# Altium schematic sheet power_supply.SchDoc
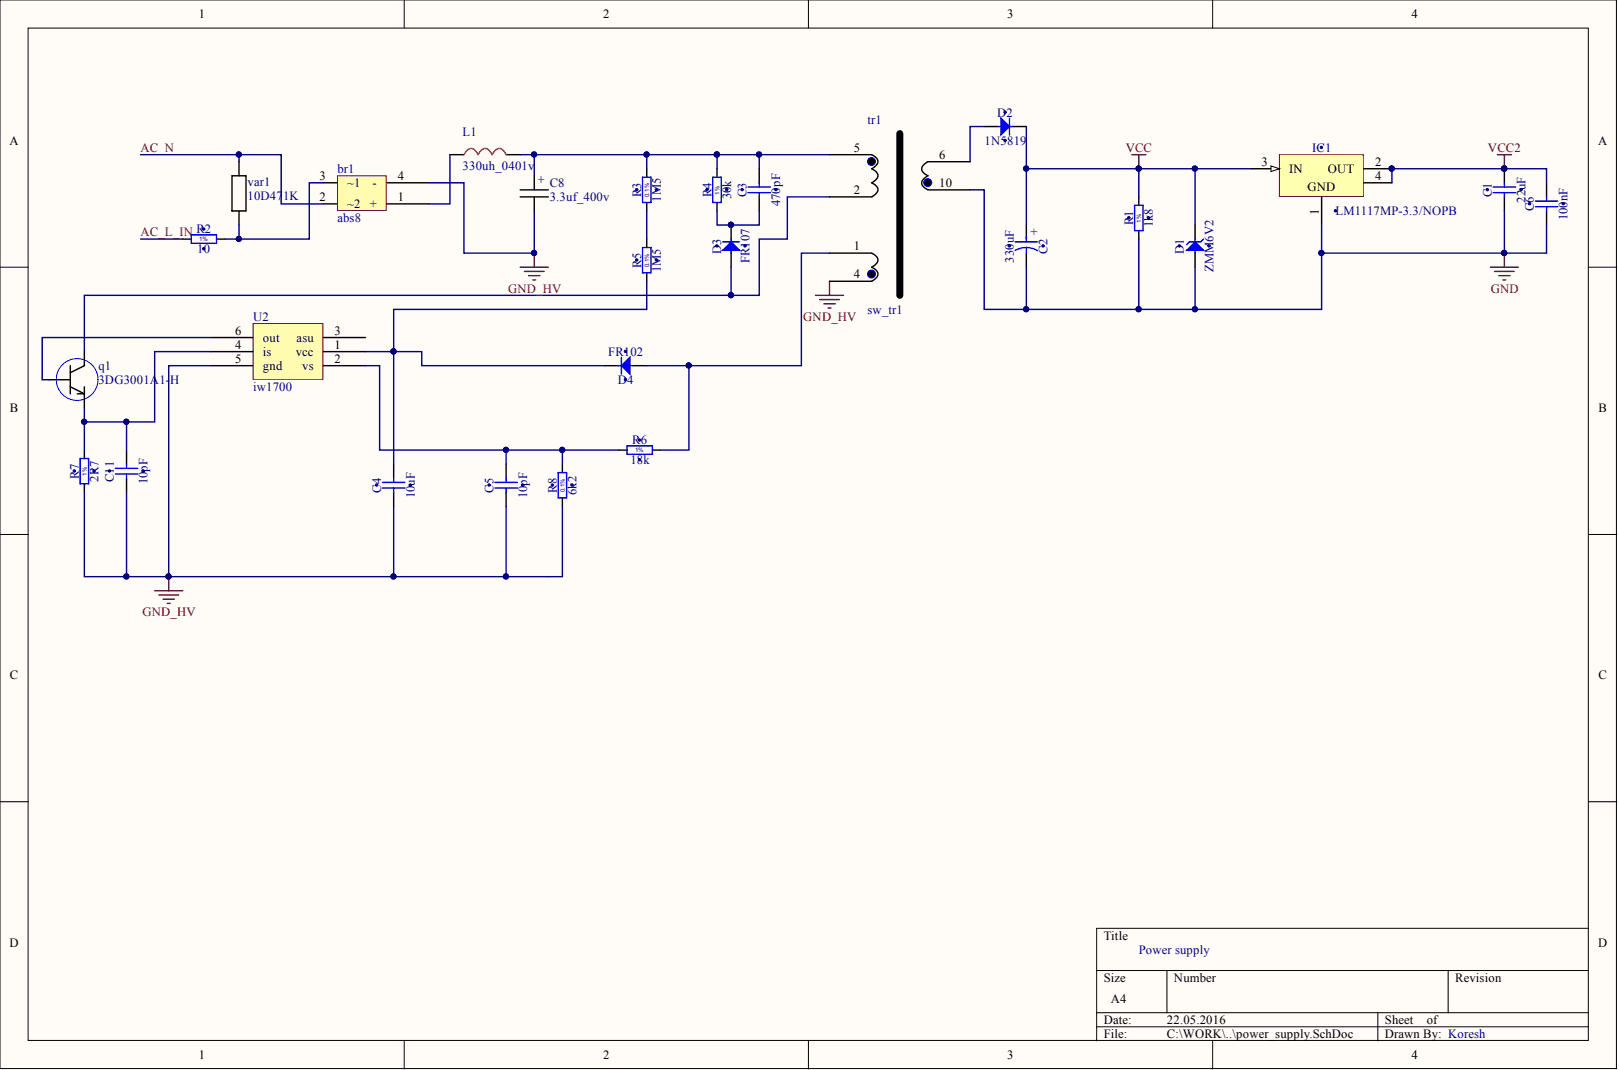
[diagram p0: power_supply.SchDoc]

## Parts
br1  abs8  [abs8]
C1  22uF  [CAPC3216X180N]
C2  330uF  [CAPMP7343X310N]
C3  470pF  [CAPC1608X87N]
C4  10uF  [CAPC2012X135N]
C5  10pF  [CAPC1608X87N]
C6  100nF  [CAPC1608X87N]
C8  3.3uf_400v  [3.3uf_400v]
C11  10pF  [CAPC1005X55N]
D1  ZMM6V2  [DIOMELF3516N]
D2  1N5819  [DIOM5227X270N]
D3  FR107  [DIOM5227X270N]
D4  FR102  [DIOM5227X270N]
IC1  LM1117MP-3.3/NOPB  [SOT230P700X180-4N]
L1  330uh_0401v  [330uh_0401v]
q1  3DG3001A1-H  [TO-92AA-P254]
R1  1k8  [RESC1608X55N]
R2  10  [RESC6331X70N]
R3  1M5  [RESC3216X65N]
R4  30k  [RESC1608X55N]
R5  1M5  [RESC3216X65N]
R6  18k  [RESC1608X55N]
R7  2R7  [RESC2012X60N]
R8  6k2  [RESC1608X55N]
tr1  sw_tr1  [sw_tr1]
U2  iw1700  [SOT95P280X100-6N]
var1  10D471K  [10D471K]

## Nets
GND: C1.1 C2.2 C6.1 D1.2 IC1.1 R1.1 tr1.10
GND_HV: br1.4(-) C4.1 C5.1 C8.2 C11.1 R7.1 R8.1 tr1.4 U2.5(gnd)
VCC: C2.1 D1.1 D2.1 IC1.3(IN) R1.2
VCC2: C1.2 C6.2 IC1.2(OUT) IC1.4
AC_L_IN: R2.1
AC_N: br1.2(~2) var1.2
Netbr1_1: br1.1(+) L1.1
Netbr1_3: br1.3(~1) R2.2 var1.1
NetC3_1: C3.1 D3.1 R4.1
NetC3_2: C3.2 C8.1 L1.2 R3.2 R4.2 tr1.5
NetC4_2: C4.2 D4.1 R5.1 U2.1(vcc)
NetC5_2: C5.2 R6.1 R8.2 U2.2(vs)
NetC11_2: C11.2 q1.3 R7.2 U2.4(is)
NetD2_2: D2.2 tr1.6
NetD3_2: D3.2 q1.2 tr1.2
NetD4_2: D4.2 R6.2 tr1.1
Netq1_1: q1.1 U2.6(out)
NetR3_1: R3.1 R5.2

Not connected: U2 3(asu)

## Library data of the parts
C1 = CC1206_22UF_10V_10%_X7R: Non-polarised capacitor; Manufacturer: GENERIC; Manufacturer1 Part Number: GRM31CR71A226KE15L; Part Description: SMD Multilayer Chip Ceramic Capacitor; Database Table Name: Capacitors SMD; TC: X7R; Tolerance: ±10%; Voltage: 10V; Case: 1206; Component Kind: Standard; Component Type: Standard; CreateDate: 08.06.2010; HelpURL: \\cern.ch\dfs\Applications\Altium\Datasheets\CC1206_X7R_MURATA_GRM-HI-CAP.pdf; LatestRevisionDate: 08.06.2010; Library Name: Capacitors.DbLib; Manufacturer1 ComponentHeight: 1.8mm; Manufacturer1 Example: MURATA; Manufacturer Part Number: CC1206_22UF_10V_10%_X7R; Mounted: Yes; Part Number: CC1206_22UF_10V_10%_X7R; Pin Count: 2; SMD: Yes; Socket: No; Status: Preferred; Table: Capacitors SMD; Value: 22uF; Author: CERN DEM JLC
C2 = CTE7343-31_330UF_6.3V_20%_LESR0025_KEMET_T520: Polarised capacitor; Manufacturer: KEMET; Manufacturer Part Number: T520D337M006ATE025; Part Description: Low ESR 0R025 Tantalum Chip Capacitor; Database Table Name: Capacitors SMD; Tolerance: ±20%; Voltage: 6.3V; Case: D; ComponentHeight: 3.1mm; Component Kind: Standard; Component Type: Standard; CreateDate: 20.10.2010; HelpURL: \\cern.ch\dfs\Applications\Altium\Datasheets\CTE-LESR_KEMET_T520.pdf; LatestRevisionDate: 20.10.2010; Library Name: Capacitors.DbLib; Mounted: Yes; Part Number: CTE7343-31_330UF_6.3V_20%_LESR0025_KEMET_T520; Pin Count: 2; SMD: Yes; Socket: No; Status: None; Table: Capacitors SMD; Value: 330uF; Author: CERN DEM JLC
C3 = CC0603_470PF_50V_5%_NP0: Non-polarised capacitor; Manufacturer: GENERIC; Manufacturer1 Part Number: 223886715471; Part Description: SMD Multilayer Chip Ceramic Capacitor; Database Table Name: Capacitors SMD; TC: NP0; Tolerance: ±5%; Voltage: 50V; Case: 0603; Component Kind: Standard; Component Type: Standard; CreateDate: 03.12.2007; HelpURL: \\cern.ch\dfs\Applications\Altium\Datasheets\CC0603_NP0_PHYCOMP.pdf; LatestRevisionDate: 03.12.2007; Library Name: Capacitors.DbLib; Manufacturer1 ComponentHeight: 0.87mm; Manufacturer1 Example: PHYCOMP; Manufacturer Part Number: CC0603_470PF_50V_5%_NP0; Mounted: Yes; Part Number: CC0603_470PF_50V_5%_NP0; Pin Count: 2; SMD: Yes; Socket: No; Status: Preferred; Table: Capacitors SMD; Value: 470pF; Author: CERN DEM JLC
C4 = CC0805_10UF_25V_10%_X5R: Non-polarised capacitor; Manufacturer: GENERIC; Manufacturer1 Part Number: C2012X5R1E106K125AB; Part Description: SMD Multilayer Chip Ceramic Capacitor; Database Table Name: Capacitors SMD; TC: X5R; Tolerance: ±10%; Voltage: 25V; Case: 0805; Component Kind: Standard; Component Type: Standard; CreateDate: 25.10.2012; HelpURL: \\cern.ch\dfs\Applications\Altium\Datasheets\CC0805_X5R_TDK_C.pdf; LatestRevisionDate: 25.10.2012; Library Name: Capacitors.DbLib; Manufacturer1 ComponentHeight: 1.4mm; Manufacturer1 Example: TDK; Manufacturer Part Number: CC0805_10UF_25V_10%_X5R; Mounted: Yes; Part Number: CC0805_10UF_25V_10%_X5R; Pin Count: 2; SMD: Yes; Socket: No; Status: Preferred; Table: Capacitors SMD; Value: 10uF; Author: CERN DEM JLC
C5 = CC0603_10PF_50V_5%_NP0: Non-polarised capacitor; Manufacturer: GENERIC; Manufacturer1 Part Number: 223886715109; Part Description: SMD Multilayer Chip Ceramic Capacitor; Database Table Name: Capacitors SMD; TC: NP0; Tolerance: ±5%; Voltage: 50V; Case: 0603; Component Kind: Standard; Component Type: Standard; CreateDate: 03.12.2007; HelpURL: \\cern.ch\dfs\Applications\Altium\Datasheets\CC0603_NP0_PHYCOMP.pdf; LatestRevisionDate: 03.12.2007; Library Name: Capacitors.DbLib; Manufacturer1 ComponentHeight: 0.87mm; Manufacturer1 Example: PHYCOMP; Manufacturer Part Number: CC0603_10PF_50V_5%_NP0; Mounted: Yes; Part Number: CC0603_10PF_50V_5%_NP0; Pin Count: 2; SMD: Yes; Socket: No; Status: Preferred; Table: Capacitors SMD; Value: 10pF; Author: CERN DEM JLC
C6 = CC0603_100NF_50V_10%_X7R: Non-polarised capacitor; Manufacturer: GENERIC; Manufacturer1 Part Number: 223858615649; Part Description: SMD Multilayer Chip Ceramic Capacitor; Database Table Name: Capacitors SMD; TC: X7R; Tolerance: ±10%; Voltage: 50V; Case: 0603; Component Kind: Standard; Component Type: Standard; CreateDate: 03.12.2007; HelpURL: \\cern.ch\dfs\Applications\Altium\Datasheets\CC0603_X7R_PHYCOMP.pdf; LatestRevisionDate: 03.12.2007; Library Name: Capacitors.DbLib; Manufacturer1 ComponentHeight: 0.87mm; Manufacturer1 Example: PHYCOMP; Manufacturer Part Number: CC0603_100NF_50V_10%_X7R; Mounted: Yes; Part Number: CC0603_100NF_50V_10%_X7R; Pin Count: 2; SMD: Yes; Socket: No; Status: Preferred; Table: Capacitors SMD; Value: 100nF; Author: CERN DEM JLC
C11 = CC0402_10PF_50V_5%_NP0: Non-polarised capacitor; Manufacturer: GENERIC; Manufacturer1 Part Number: 223886915109; Part Description: SMD Multilayer Chip Ceramic Capacitor; Database Table Name: Capacitors SMD; TC: NP0; Tolerance: ±5%; Voltage: 50V; Case: 0402; Component Kind: Standard; Component Type: Standard; CreateDate: 03.12.2007; HelpURL: \\cern.ch\dfs\Applications\Altium\Datasheets\CC0402_NP0_PHYCOMP.pdf; LatestRevisionDate: 03.12.2007; Library Name: Capacitors.DbLib; Manufacturer1 ComponentHeight: 0.55mm; Manufacturer1 Example: PHYCOMP; Manufacturer Part Number: CC0402_10PF_50V_5%_NP0; Mounted: Yes; Part Number: CC0402_10PF_50V_5%_NP0; Pin Count: 2; SMD: Yes; Socket: No; Status: Not Recommended; Table: Capacitors SMD; Value: 10pF; Author: CERN DEM JLC
D1 = CDLL4689E3: Zener Diode; Manufacturer: MICROSEMI; Part Description: Low Operating Current Zener Diode; Database Table Name: Diodes; Power: 500mW; Voltage: 5V1; Case: SOD-80; ComponentHeight: 1.7mm; Component Kind: Standard; Component Type: Standard; CreateDate: 11.04.2011; Device: ZMM6V2; HelpURL: \\cern.ch\dfs\Applications\Altium\Datasheets\CDLL4689E3.pdf; LatestRevisionDate: 11.04.2011; Library Name: ICs And Semiconductors.DbLib; Manufacturer Part Number: ZMM6V2; Mounted: Yes; PackageDescription: SOD80, Diode, MELF; 3.50mm L X 1.65mm Dia, IPC Medium Density; Part Number: ZMM6V2; Pin Count: 2; SMD: Yes; Socket: No; Table: Diodes; Author: CERN DEM JLC
D2 D3 = S1M: Diode; Manufacturer: FAIRCHILD SEMICONDUCTOR; Part Description: Surface Mount Glass Passivated Rectifier; Database Table Name: Diodes; Power: 1A; Voltage: 1000V; Case: DO-214AC; ComponentHeight: 2.29mm; Component Kind: Standard; Component Type: Standard; CreateDate: 03.10.2011; HelpURL: \\cern.ch\dfs\Applications\Altium\Datasheets\S1M.pdf; LatestRevisionDate: 13.06.2012; Library Name: ICs And Semiconductors.DbLib; Mounted: Yes; PackageDescription: DO-214-AC/SMA Diode, Molded Body;5.20mm L X 2.725mm W X 2.70mm H, IPC Medium Density; Pin Count: 2; SMD: Yes; Socket: No; Table: Diodes; Author: CERN DEM JLC
  D2: Device: 1N5819; Manufacturer Part Number: 1N5819; Part Number: 1N5819
  D3: Device: FR107; Manufacturer Part Number: FR107; Part Number: FR107
D4 = S1M: Diode; Manufacturer: FAIRCHILD SEMICONDUCTOR; Part Description: Surface Mount Glass Passivated Rectifier; Part Number: FR107; Database Table Name: Diodes; Power: 1A; Voltage: 1000V; Case: DO-214AC; ComponentHeight: 2.29mm; Component Kind: Standard; Component Type: Standard; CreateDate: 03.10.2011; Device: FR102; HelpURL: \\cern.ch\dfs\Applications\Altium\Datasheets\S1M.pdf; LatestRevisionDate: 13.06.2012; Library Name: ICs And Semiconductors.DbLib; Manufacturer Part Number: FR102; Mounted: Yes; PackageDescription: DO-214-AC/SMA Diode, Molded Body;5.20mm L X 2.725mm W X 2.70mm H, IPC Medium Density; Pin Count: 2; SMD: Yes; Socket: No; Table: Diodes; Author: CERN DEM JLC
IC1 = LM1117MP-1.8/NOPB: 3-Terminal Regulator 1=GND 2=OUT 3=IN 4=OUT; Manufacturer: NATIONAL SEMICONDUCTOR; Part Description: 1.8V 800mA Low-Dropout Linear Regulator; Database Table Name: Regulators; Case: SOT223; ComponentHeight: 1.8mm; Component Kind: Standard; Component Type: Standard; CreateDate: 18.01.2011; Device: LM1117MP-3.3/NOPB; HelpURL: \\cern.ch\dfs\Applications\Altium\Datasheets\LM1117MP-1.8-NOPB.pdf; LatestRevisionDate: 18.01.2011; Library Name: ICs And Semiconductors.DbLib; Manufacturer Part Number: LM1117MP-1.8/NOPB; Mounted: Yes; PackageDescription: SOT223, 2.30mm pitch; 3 pin, 3.70mm W X 6.70mm L, IPC Medium Density; Part Number: LM1117MP-3.3/NOPB; Pin Count: 4; SMD: Yes; Socket: No; Table: Regulators; Author: CERN DEM JLC
R1 = R0603_1K8_1%_0.1W_100PPM: Resistor - 1%; Manufacturer: GENERIC; Manufacturer1 Part Number: NRC06F1801TRF; Part Description: General Purpose Thick Film Chip Resistor; Database Table Name: Resistors SMD; Power: 0.1W; TC: ±100ppm/°C; Case: 0603; Component Kind: Standard; Component Type: Standard; CreateDate: 03.12.2007; HelpURL: \\cern.ch\dfs\Applications\Altium\Datasheets\R0603_NIC_NRC.pdf; LatestRevisionDate: 13.03.2008; Library Name: Resistors.DbLib; Manufacturer1 ComponentHeight: 0.55mm; Manufacturer1 Example: NIC COMPONENT; Manufacturer Part Number: R0603_1K8_1%_0.1W_100PPM; Mounted: Yes; Part Number: R0603_1K8_1%_0.1W_100PPM; Pin Count: 2; SMD: Yes; Socket: No; Status: Preferred; Table: Resistors SMD; Tolerance: ±1%; Value: 1k8; Author: CERN DEM JLC
R2 = R2512_10R_1%_1W_200PPM: Resistor - 1%; Manufacturer: GENERIC; Manufacturer1 Part Number: 232276361009L or RC2512FK-0710RL; Part Description: Thick Film Chip Resistor; Database Table Name: Resistors SMD; Power: 1W; TC: ±200ppm/°C; Case: 2512; Component Kind: Standard; Component Type: Standard; CreateDate: 21.07.2008; HelpURL: \\cern.ch\dfs\Applications\Altium\Datasheets\R2512_Phycomp_RC2512.pdf; LatestRevisionDate: 17.10.2012; Library Name: Resistors.DbLib; Manufacturer1 ComponentHeight: 0.65mm; Manufacturer1 Example: YAGEO PHYCOMP; Manufacturer Part Number: R2512_10R_1%_1W_200PPM; Mounted: Yes; Part Number: R2512_10R_1%_1W_200PPM; Pin Count: 2; SMD: Yes; Socket: No; Status: Preferred; Table: Resistors SMD; Tolerance: ±1%; Value: 10; Author: CERN DEM JLC
R3 R5 = R1206_1M_0.1%_0.25W_25PPM: Resistor - 0.1%; Manufacturer: GENERIC; Manufacturer1 Part Number: TNPW12061M00BEEN; Part Description: Thin Film Chip Resistor; Part Number: R1206_1M5_0.1%_0.25W_25PPM; Database Table Name: Resistors SMD; Power: 0.25W; TC: ±25ppm/°C; Case: 1206; Component Kind: Standard; Component Type: Standard; CreateDate: 18.01.2012; HelpURL: \\cern.ch\dfs\Applications\Altium\Datasheets\R1206_VISHAY_TNPW E3.pdf; LatestRevisionDate: 18.01.2012; Library Name: Resistors.DbLib; Manufacturer1 ComponentHeight: 0.65mm; Manufacturer1 Example: VISHAY; Manufacturer Part Number: R1206_1M_0.1%_0.25W_25PPM; Mounted: Yes; Pin Count: 2; SMD: Yes; Socket: No; Status: Not Recommended; Table: Resistors SMD; Tolerance: ±0.1%; Value: 1M5; Author: CERN DEM JLC
R4 = R0603_30K_1%_0.1W_100PPM: Resistor - 1%; Manufacturer: GENERIC; Manufacturer1 Part Number: NRC06F3002TRF; Part Description: General Purpose Thick Film Chip Resistor; Database Table Name: Resistors SMD; Power: 0.1W; TC: ±100ppm/°C; Case: 0603; Component Kind: Standard; Component Type: Standard; CreateDate: 03.12.2007; HelpURL: \\cern.ch\dfs\Applications\Altium\Datasheets\R0603_NIC_NRC.pdf; LatestRevisionDate: 13.03.2008; Library Name: Resistors.DbLib; Manufacturer1 ComponentHeight: 0.55mm; Manufacturer1 Example: NIC COMPONENT; Manufacturer Part Number: R0603_30K_1%_0.1W_100PPM; Mounted: Yes; Part Number: R0603_30K_1%_0.1W_100PPM; Pin Count: 2; SMD: Yes; Socket: No; Status: Preferred; Table: Resistors SMD; Tolerance: ±1%; Value: 30k; Author: CERN DEM JLC
R6 = R0603_18K_1%_0.1W_100PPM: Resistor - 1%; Manufacturer: GENERIC; Manufacturer1 Part Number: NRC06F1802TRF; Part Description: General Purpose Thick Film Chip Resistor; Database Table Name: Resistors SMD; Power: 0.1W; TC: ±100ppm/°C; Case: 0603; Component Kind: Standard; Component Type: Standard; CreateDate: 03.12.2007; HelpURL: \\cern.ch\dfs\Applications\Altium\Datasheets\R0603_NIC_NRC.pdf; LatestRevisionDate: 13.03.2008; Library Name: Resistors.DbLib; Manufacturer1 ComponentHeight: 0.55mm; Manufacturer1 Example: NIC COMPONENT; Manufacturer Part Number: R0603_18K_1%_0.1W_100PPM; Mounted: Yes; Part Number: R0603_18K_1%_0.1W_100PPM; Pin Count: 2; SMD: Yes; Socket: No; Status: Preferred; Table: Resistors SMD; Tolerance: ±1%; Value: 18k; Author: CERN DEM JLC
R7 = R0805_4R3_1%_0.125W_200PPM: Resistor - 1%; Manufacturer: GENERIC; Manufacturer1 Part Number: 232273464308L or RC0805FR-074R3L; Part Description: General Purpose Thick Film Chip Resistor; Part Number: R0805_2R7_1%_0.125W_200PPM; Database Table Name: Resistors SMD; Power: 0.125W; TC: ±200ppm/°C; Case: 0805; Component Kind: Standard; Component Type: Standard; CreateDate: 03.12.2007; HelpURL: \\cern.ch\dfs\Applications\Altium\Datasheets\R0805_Phycomp_RC0805.pdf; LatestRevisionDate: 17.10.2012; Library Name: Resistors.DbLib; Manufacturer1 ComponentHeight: 0.65mm; Manufacturer1 Example: YAGEO PHYCOMP; Manufacturer Part Number: R0805_4R3_1%_0.125W_200PPM; Mounted: Yes; Pin Count: 2; SMD: Yes; Socket: No; Status: Preferred; Table: Resistors SMD; Tolerance: ±1%; Value: 2R7; Author: CERN DEM JLC
R8 = R0603_4K32_0.1%_0.063W_10PPM: Resistor - 0.1%; Manufacturer: GENERIC; Manufacturer1 Part Number: RN73C1J4K32BTDF; Part Description: Thin Film Chip Resistor; Part Number: R0603_6K2_0.1%_0.063W_10PPM; Database Table Name: Resistors SMD; Power: 0.063W; TC: ±10ppm; Case: 0603; Component Kind: Standard; Component Type: Standard; CreateDate: 03.12.2007; HelpURL: \\cern.ch\dfs\Applications\Altium\Datasheets\R0603_Tyco_RN73.pdf; LatestRevisionDate: 13.03.2008; Library Name: Resistors.DbLib; Manufacturer1 ComponentHeight: 0.5mm; Manufacturer1 Example: TYCO HOLSWORTHY; Manufacturer Part Number: R0603_4K32_0.1%_0.063W_10PPM; Mounted: Yes; Pin Count: 2; SMD: Yes; Socket: No; Status: Not recommended; Table: Resistors SMD; Tolerance: ±0.1%; Value: 6k2; Author: CERN DEM JLC
br1 = abs8: Component Kind: Standard; Library Name: trojan1.SchLib
C8 = 3.3uf_400v: Component Kind: Standard; Library Name: trojan1.SchLib
L1 = 330uh_0401v: Component Kind: Standard; Library Name: trojan1.SchLib
q1 = 3DG3001A1-H: Component Kind: Standard; Library Name: trojan1.SchLib
tr1 = sw_tr1: Component Kind: Standard; Library Name: trojan1.SchLib
U2 = iw1700: Component Kind: Standard; Library Name: trojan1.SchLib
var1 = 10D471K: Component Kind: Standard; Library Name: trojan1.SchLib
